# Altium schematic sheet CW308T-FE310-G002.SchDoc
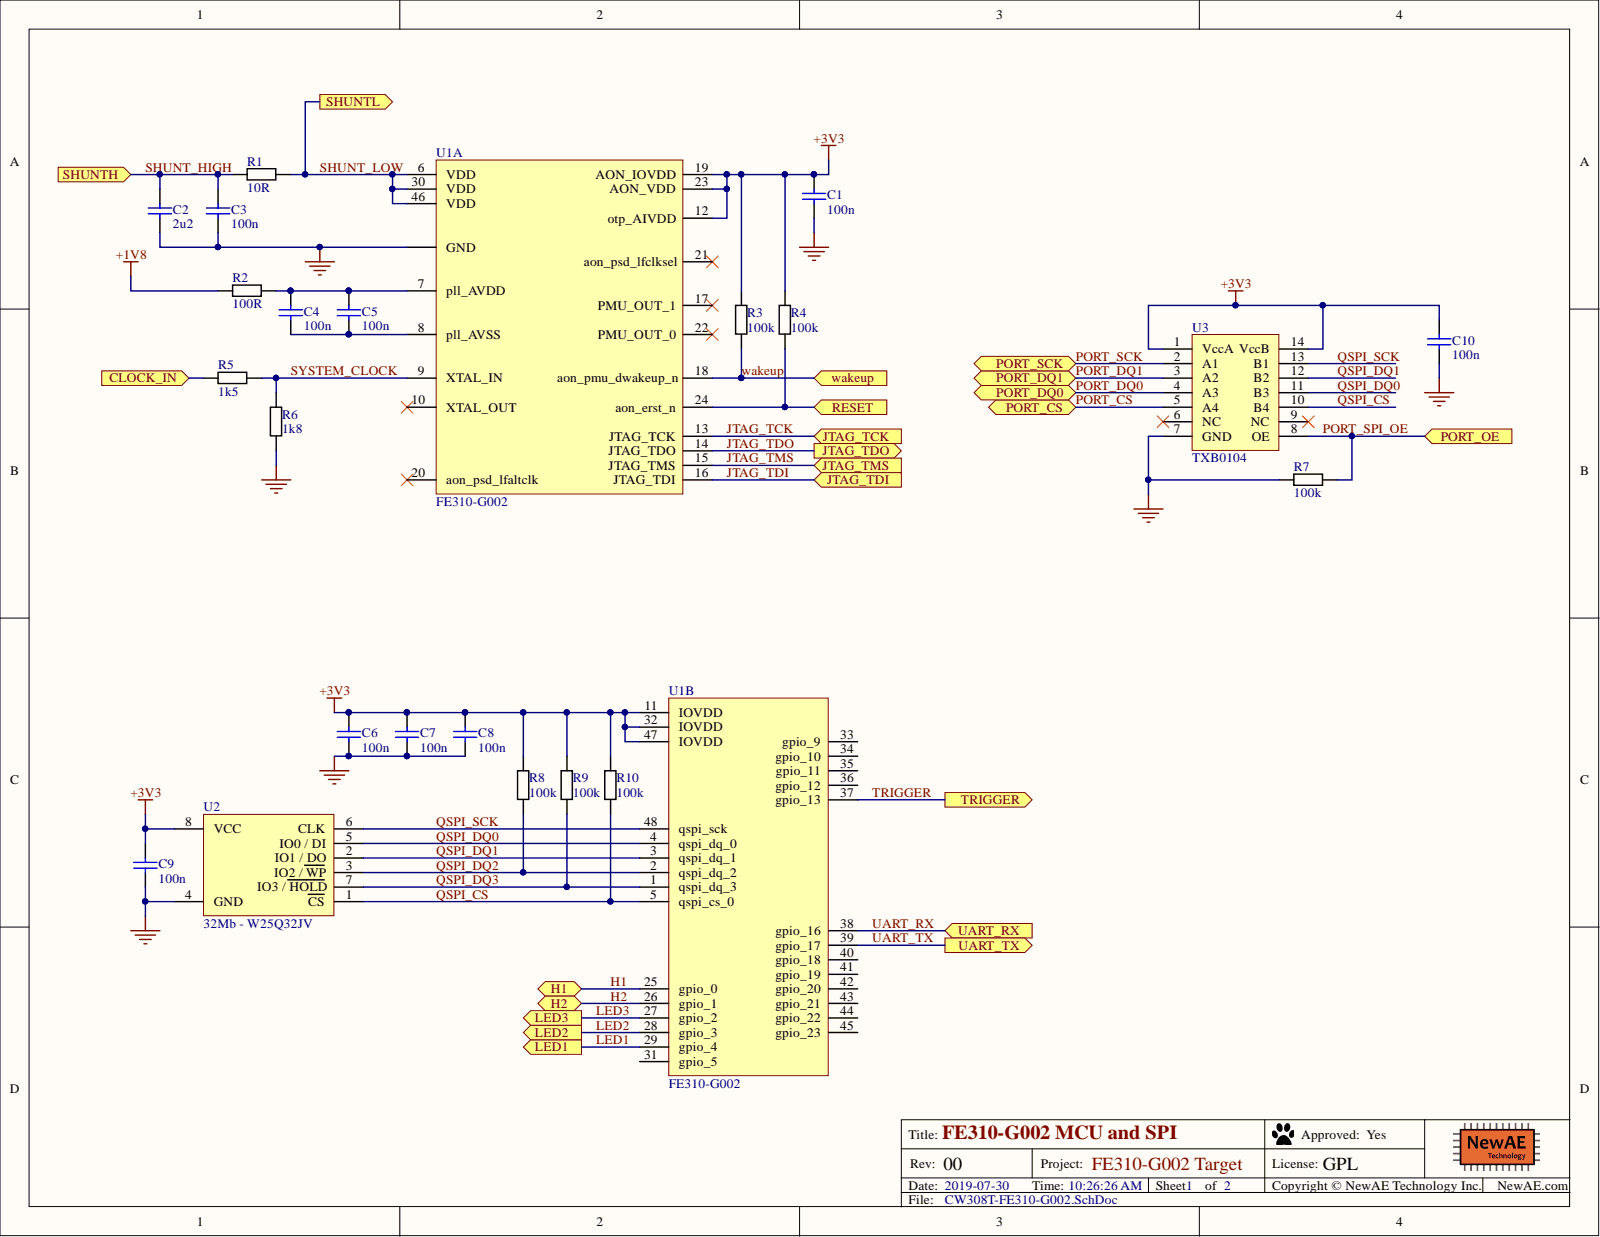
[diagram p0: CW308T-FE310-G002.SchDoc]

## Parts
C1  100n  [0603]
C2  2u2  [0603]
C3  100n  [0603]
C4  100n  [0603]
C5  100n  [0603]
C6  100n  [0603]
C7  100n  [0603]
C8  100n  [0603]
C9  100n  [0603]
C10  100n  [0603]
R1  10R  [0603]
R2  100R  [0603]
R3  100k  [0603]
R4  100k  [0603]
R5  1k5  [0603]
R6  1k8  [0603]
R7  100k  [0603]
R8  100k  [0603]
R9  100k  [0603]
R10  100k  [0603]
U1  FE310-G002  [QFN-48]  (parts A,B)
U2  32Mb - W25Q32JV  value 32Mb  [8SOIC]
U3  TXB0104  [SOIC14 (R-PDSO-G14)]

## Ports
CLOCK_IN, H1, H2, JTAG_TCK, JTAG_TDI, JTAG_TDO, JTAG_TMS, LED1, LED2, LED3, PORT_CS, PORT_DQ0, PORT_DQ1, PORT_OE, PORT_SCK, RESET, SHUNTH, SHUNTL, TRIGGER, UART_RX, UART_TX, wakeup

## Nets
+1V8: R2.1
+3V3: C1.1 C6.1 C7.1 C8.1 C9.1 C10.1 R3.1 R4.1 R8.1 R9.1 R10.1 U1.11(IOVDD) U1.12(otp_AIVDD) U1.19(AON_IOVDD) U1.23(AON_VDD) U1.32(IOVDD) U1.47(IOVDD) U2.8(VCC) U3.1(VccA) U3.14(VccB)
GND: C1.2 C2.2 C3.2 C6.2 C7.2 C8.2 C9.2 C10.2 R6.2 R7.1 U1.PAD(GND) U2.4(GND) U3.7(GND)
CLOCK_IN: R5.1 ; port CLOCK_IN
H1: U1.25(gpio_0) ; port H1
H2: U1.26(gpio_1) ; port H2
JTAG_TCK: U1.13(JTAG_TCK) ; port JTAG_TCK
JTAG_TDI: U1.16(JTAG_TDI) ; port JTAG_TDI
JTAG_TDO: U1.14(JTAG_TDO) ; port JTAG_TDO
JTAG_TMS: U1.15(JTAG_TMS) ; port JTAG_TMS
LED1: U1.29(gpio_4) ; port LED1
LED2: U1.28(gpio_3) ; port LED2
LED3: U1.27(gpio_2) ; port LED3
PORT_CS: U3.5(A4) ; port PORT_CS
PORT_DQ0: U3.4(A3) ; port PORT_DQ0
PORT_DQ1: U3.3(A2) ; port PORT_DQ1
PORT_SCK: U3.2(A1) ; port PORT_SCK
PORT_SPI_OE: R7.2 U3.8(OE) ; port PORT_OE
QSPI_CS: R10.2 U1.5(qspi_cs_0) U2.1(CS) U3.10(B4)
QSPI_DQ0: U1.4(qspi_dq_0) U2.5(IO0_/_DI) U3.11(B3)
QSPI_DQ1: U1.3(qspi_dq_1) U2.2(IO1_/_DO) U3.12(B2)
QSPI_DQ2: R8.2 U1.2(qspi_dq_2) U2.3(IO2_/_WP)
QSPI_DQ3: R9.2 U1.1(qspi_dq_3) U2.7(IO3_/_HOLD)
QSPI_SCK: U1.48(qspi_sck) U2.6(CLK) U3.13(B1)
RESET: R4.2 U1.24(aon_erst_n) ; port RESET
SHUNT_HIGH: C2.1 C3.1 R1.1 ; port SHUNTH
SHUNT_LOW: R1.2 U1.6(VDD) U1.30(VDD) U1.46(VDD) ; port SHUNTL
SYSTEM_CLOCK: R5.2 R6.1 U1.9(XTAL_IN)
TRIGGER: U1.37(gpio_13) ; port TRIGGER
UART_RX: U1.38(gpio_16) ; port UART_RX
UART_TX: U1.39(gpio_17) ; port UART_TX
wakeup: R3.2 U1.18(aon_pmu_dwakeup_n) ; port wakeup
NetC4_1: C4.1 C5.1 R2.2 U1.7(pll_AVDD)
NetC4_2: C4.2 C5.2 U1.8(pll_AVSS)

Not connected: U1 10(XTAL_OUT) 17(PMU_OUT_1) 20(aon_psd_lfaltclk) 21(aon_psd_lfclksel) 22(PMU_OUT_0) 31(gpio_5) 33(gpio_9) 34(gpio_10) 35(gpio_11) 36(gpio_12) 40(gpio_18) 41(gpio_19) 42(gpio_20) 43(gpio_21) 44(gpio_22) 45(gpio_23); U3 6(NC) 9(NC)

## Library data of the parts
C1 C3-C10 = CCHIP-100n-0603: 100n, 0603; Manufacture 1: Kemet; Manufacture Part Number 1: C0603C104K5RACTU; Case-Metric: 1608; Component Group: Capacitor; Database Table Name: main; Rated Voltage: 50VDC; Case-EIA: 0603; Component Kind: Standard; Component Type: Standard; Library Name: NewAE_AltiumLib.DBLib; Mounting Technology: SMT; Part Number: CCHIP-100n-0603; Pin Count: 2; Supplier 1: Digi-Key; Supplier Part Number 1: 399-5089-2-ND; Value: 100n
C2 = CCHIP-2u2-0603: 2u2, 0603; Manufacture 1: Samsung; Manufacture Part Number 1: CL10B225KP8NNNC; Case-Metric: 1608; Component Group: Capacitor; Database Table Name: main; Rated Voltage: 10VDC; Case-EIA: 0603; Component Kind: Standard; Component Type: Standard; Library Name: NewAE_AltiumLib.DBLib; Mounting Technology: SMT; Part Number: CCHIP-2u2-0603; Pin Count: 2; Supplier 1: Digi-Key; Supplier Part Number 1: 1276-1134-2-ND; Value: 2u2
R1 = RCHIP-10R-0603: 10R res, 0603, 1%, 1/10W; Manufacture 1: Yageo; Manufacture Part Number 1: RC0603FR-0710RL; Case-Metric: 1608; Component Group: Resistor; Database Table Name: main; Rated Power: 0.1W; Rated Voltage: 75VDC; Case-EIA: 0603; Component Kind: Standard; Component Type: Standard; Library Name: NewAE_AltiumLib.DBLib; Mounting Technology: SMT; Part Number: RCHIP-10R-0603; Pin Count: 2; Supplier 1: Digi-Key; Supplier Part Number 1: 311-10.0HRCT-ND; Temperature Range: -55C to 155C; Tolerance: 1%; Value: 10R
R2 = RCHIP-100R-0603: 100R res, 0603, 1%, 1/10W; Manufacture 1: Yageo; Manufacture Part Number 1: RC0603FR-07100RL; Case-Metric: 1608; Component Group: Resistor; Database Table Name: main; Rated Power: 0.1W; Rated Voltage: 75VDC; Case-EIA: 0603; Component Kind: Standard; Component Type: Standard; Library Name: NewAE_AltiumLib.DBLib; Mounting Technology: SMT; Part Number: RCHIP-100R-0603; Pin Count: 2; Supplier 1: Digi-Key; Supplier Part Number 1: 311-100HRCT-ND; Temperature Range: -55C to 155C; Tolerance: 1%; Value: 100R
R3 R4 R7-R10 = RCHIP-100K-0603: 100k res, 0603, 1%, 1/10W; Manufacture 1: Yageo; Manufacture Part Number 1: RC0603FR-07100KL; Case-Metric: 1608; Component Group: Resistor; Database Table Name: main; Rated Power: 0.1W; Rated Voltage: 75VDC; Case-EIA: 0603; Component Kind: Standard; Component Type: Standard; Library Name: NewAE_AltiumLib.DBLib; Mounting Technology: SMT; Part Number: RCHIP-100K-0603; Pin Count: 2; Supplier 1: Digi-Key; Supplier Part Number 1: 311-100KHRCT-ND; Temperature Range: -55C to 155C; Tolerance: 1%; Value: 100k
R5 = RCHIP-1K5-0603: 1k5 res, 0603, 1%, 1/10W; Manufacture 1: Yageo; Manufacture Part Number 1: RC0603FR-071K5L; Case-Metric: 1608; Component Group: Resistor; Database Table Name: main; Rated Power: 0.1W; Rated Voltage: 75VDC; Case-EIA: 0603; Component Kind: Standard; Component Type: Standard; Library Name: NewAE_AltiumLib.DBLib; Mounting Technology: SMT; Part Number: RCHIP-1K5-0603; Pin Count: 2; Supplier 1: Digi-Key; Supplier Part Number 1: 311-1.50KHRCT-ND; Temperature Range: -55C to 155C; Tolerance: 1%; Value: 1k5
R6 = RCHIP-1K8-0603: 1k8 res, 0603, 1%, 1/10W; Manufacture 1: Yageo; Manufacture Part Number 1: RC0603FR-071K8L; Case-Metric: 1608; Component Group: Resistor; Database Table Name: main; Rated Power: 0.1W; Rated Voltage: 75VDC; Case-EIA: 0603; Component Kind: Standard; Component Type: Standard; Library Name: NewAE_AltiumLib.DBLib; Mounting Technology: SMT; Part Number: RCHIP-1K8-0603; Pin Count: 2; Supplier 1: Digi-Key; Supplier Part Number 1: 311-1.80KHRCT-ND; Temperature Range: -55C to 155C; Tolerance: 1%; Value: 1k8
U1 = FE310-G002: RISC-V FREEDOM SoC; Manufacture 1: SiFive; Component Group: Digital IC; Database Table Name: main; PP Material Stack: 0; Component Kind: Standard; Component Type: Standard; Library Name: NewAE_AltiumLib.DBLib; Manufacture Part Number 1: FE310-G002; Mounting Technology: SMT; Part Number: FE310-G002; Pin Count: 48; PP Rotation: 0
U2 = QSPI-FLASH-32M-32Mb: IC FLASH 32M SPI 133MHZ 8SOIC; Manufacture 1: Winbond Electronics; Manufacture Part Number 1: W25Q32JVSSIQ TR; Component Group: Digital IC; Database Table Name: main; PP Material Stack: 0; Component Kind: Standard; Component Type: Standard; Library Name: NewAE_AltiumLib.DBLib; Mounting Technology: SMT; Part Number: QSPI-FLASH-32M-32Mb; Pin Count: 8; PP Rotation: 0; RoHS: Yes; Supplier 1: Digi-Key; Supplier Part Number 1: W25Q32JVSSIQCT-ND
U3 = TXB0104DR: IC 4BIT NON-INV TRANSLTR 14-SOIC; Manufacture 1: Texas Instruments; Component Group: Digital IC; Database Table Name: main; PP Material Stack: 0; Component Kind: Standard; Component Type: Standard; Library Name: NewAE_AltiumLib.DBLib; Manufacture Part Number 1: TXB0104DR; Mounting Technology: SMT; Part Number: TXB0104DR; Pin Count: 14; PP Rotation: 0; RoHS: Yes; Supplier 1: Digi-Key; Supplier Part Number 1: 296-21928-1-ND
